# Altium schematic sheet Main.SchDoc
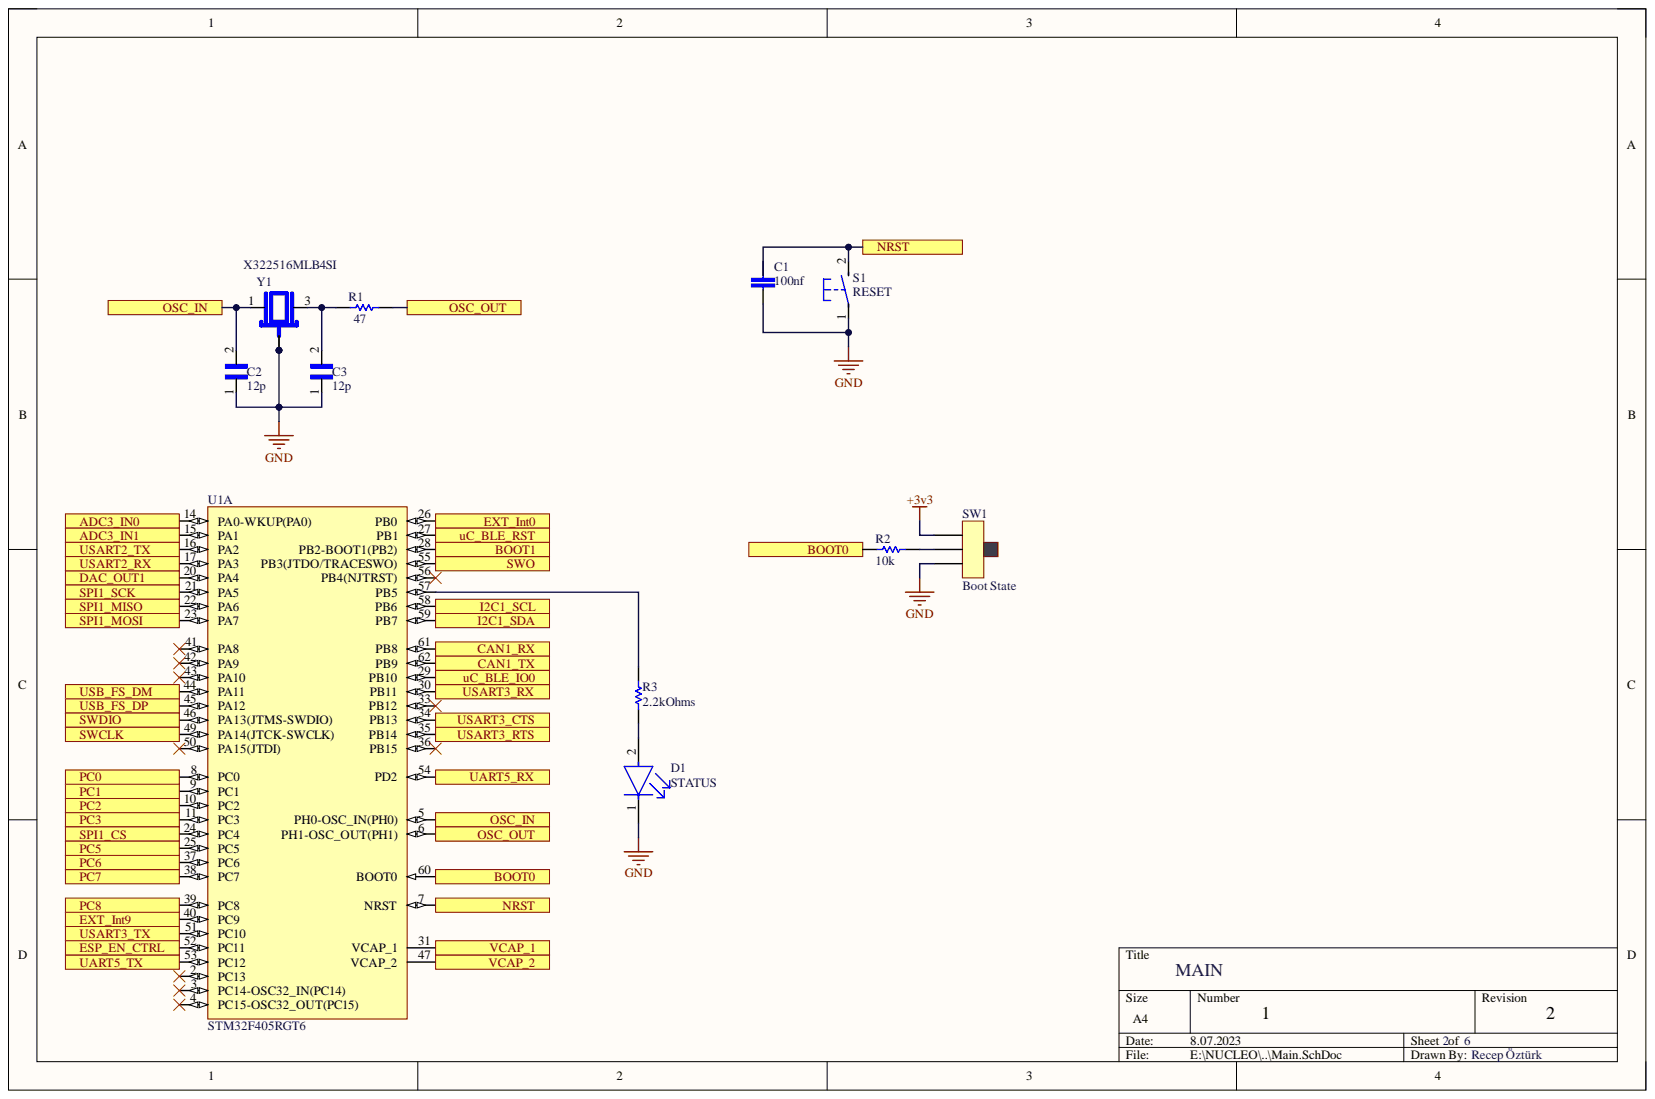
[diagram p0: Main.SchDoc]

## Parts
C1  100nf  [CAPC1005X55N]
C2  12p  [CAPC1005X55N]
C3  12p  [CAPC1005X55N]
D1  STATUS  [FP-APT1608QBC-D-MFG]
R1  value 47  [RESC1608X50N]  (hidden comment: Res1)
R2  value 10k  [RESC1608X50N]  (hidden comment: Res1)
R3  value 2.2kOhms  [RESC1608X50N]  (hidden comment: Res1)
S1  RESET  [DTS-3]
SW1  Boot State  [Pololu Mini Slide SW 2.5mm]
U1  STM32F405RGT6  [STM-LQFP64_M]  (part A)
Y1  X322516MLB4SI  [OSC_X322516MLB4SI]

## Ports
ADC3_IN0, ADC3_IN1, BOOT0, BOOT1, CAN1_RX, CAN1_TX, DAC_OUT1, ESP_EN_CTRL, EXT_Int0, EXT_Int9, I2C1_SCL, I2C1_SDA, NRST, OSC_IN, OSC_OUT, PC0, PC1, PC2, PC3, PC5, PC6, PC7, PC8, SPI1_CS, SPI1_MISO, SPI1_MOSI, SPI1_SCK, SWCLK, SWDIO, SWO, UART5_RX, UART5_TX, uC_BLE_IO0, uC_BLE_RST, USART2_RX, USART2_TX, USART3_CTS, USART3_RTS, USART3_RX, USART3_TX, USB_FS_DM, USB_FS_DP, VCAP_1, VCAP_2

## Nets
+3v3: SW1.1
GND: C1.2 C2.1 C3.1 D1.1 S1.1 SW1.3 Y1.2 Y1.4
ADC3_IN0: U1.14(PA0-WKUP(PA0)) ; port ADC3_IN0
ADC3_IN1: U1.15(PA1) ; port ADC3_IN1
BOOT0: R2.2 U1.60(BOOT0) ; port BOOT0
BOOT1: U1.28(PB2-BOOT1(PB2)) ; port BOOT1
CAN1_RX: U1.61(PB8) ; port CAN1_RX
CAN1_TX: U1.62(PB9) ; port CAN1_TX
DAC_OUT1: U1.20(PA4) ; port DAC_OUT1
ESP_EN_CTRL: U1.52(PC11) ; port ESP_EN_CTRL
EXT_Int0: U1.26(PB0) ; port EXT_Int0
EXT_Int9: U1.40(PC9) ; port EXT_Int9
I2C1_SCL: U1.58(PB6) ; port I2C1_SCL
I2C1_SDA: U1.59(PB7) ; port I2C1_SDA
NRST: C1.1 S1.2 U1.7(NRST) ; port NRST
OSC_IN: C2.2 U1.5(PH0-OSC_IN(PH0)) Y1.1 ; port OSC_IN
OSC_OUT: R1.2 U1.6(PH1-OSC_OUT(PH1)) ; port OSC_OUT
PC0: U1.8(PC0) ; port PC0
PC1: U1.9(PC1) ; port PC1
PC2: U1.10(PC2) ; port PC2
PC3: U1.11(PC3) ; port PC3
PC5: U1.25(PC5) ; port PC5
PC6: U1.37(PC6) ; port PC6
PC7: U1.38(PC7) ; port PC7
PC8: U1.39(PC8) ; port PC8
SPI1_CS: U1.24(PC4) ; port SPI1_CS
SPI1_MISO: U1.22(PA6) ; port SPI1_MISO
SPI1_MOSI: U1.23(PA7) ; port SPI1_MOSI
SPI1_SCK: U1.21(PA5) ; port SPI1_SCK
SWCLK: U1.49(PA14(JTCK-SWCLK)) ; port SWCLK
SWDIO: U1.46(PA13(JTMS-SWDIO)) ; port SWDIO
SWO: U1.55(PB3(JTDO/TRACESWO)) ; port SWO
UART5_RX: U1.54(PD2) ; port UART5_RX
UART5_TX: U1.53(PC12) ; port UART5_TX
uC_BLE_IO0: U1.29(PB10) ; port uC_BLE_IO0
uC_BLE_RST: U1.27(PB1) ; port uC_BLE_RST
USART2_RX: U1.17(PA3) ; port USART2_RX
USART2_TX: U1.16(PA2) ; port USART2_TX
USART3_CTS: U1.34(PB13) ; port USART3_CTS
USART3_RTS: U1.35(PB14) ; port USART3_RTS
USART3_RX: U1.30(PB11) ; port USART3_RX
USART3_TX: U1.51(PC10) ; port USART3_TX
USB_FS_DM: U1.44(PA11) ; port USB_FS_DM
USB_FS_DP: U1.45(PA12) ; port USB_FS_DP
VCAP_1: U1.31(VCAP_1) ; port VCAP_1
VCAP_2: U1.47(VCAP_2) ; port VCAP_2
NetC3_2: C3.2 R1.1 Y1.3
NetD1_2: D1.2 R3.2
NetR2_1: R2.1 SW1.2
NetR3_1: R3.1 U1.57(PB5)

Not connected: U1 2(PC13) 3(PC14-OSC32_IN(PC14)) 4(PC15-OSC32_OUT(PC15)) 33(PB12) 36(PB15) 41(PA8) 42(PA9) 43(PA10) 50(PA15(JTDI)) 56(PB4(NJTRST))

## Library data of the parts
C1 = CL05B104KO5NFNC: 100nF 16v 0402  LCSC = C1525; MF: {'manufacturer': u'Samsung'}; Availability: Unavailable; Component Kind: Standard; Library Name: SnapEDA_Library.IntLib; MP: CL05B104KO5NFNC; Package: None; Price: None
C2 C3 = GRM0225C1H120JA03L: Multilayer Ceramic Capacitors MLCC - SMD/SMT 12pF 50V 0402 RoHS  LCSC = C1547; MF: {'manufacturer': u'Murata'}; Availability: Unavailable; Component Kind: Standard; Library Name: SnapEDA_Library.IntLib; MP: GRM0225C1H120JA03L; Package: None; Price: None
D1 = CMP-2000-05788-2: Light Emitting Diodes (LED) Blue 465~475nm 11.5~28.5mcd@5mA Top View 0603 RoHS C72041; Dominant Wavelength: 465nm; Forward Current: 20mA; Forward Voltage: 3.3V; Lens Color: Colorless; Lens Style: Flat; Lens Transparency: Clear; Luminous Intensity: 100mcd; Number of LEDs: 1; Viewing Angle: 120°; Case Code (Metric): 1608; Case Code (Imperial): 0603; Case/Package: 0603; Color: Blue; Component Kind: Standard; Height: 0.75mm; Lead Free: Lead Free; Length: 1.6mm; Library Name: Altium Content Vault; Max Operating Temperature: 85°C; Min Operating Temperature: -40°C; Mount: Surface Mount; Number of Pins: 2; Package Quantity: 2000; Packaging: Tape and Reel; Test Current: 20mA; Width: 0.8mm; Illumination Color: Blue
R1 = Res1: Chip Resistor - Surface Mount 47Ohms ±1% 1/10W 0603 RoHS  LCSC = C23182; Component Kind: Standard; LatestRevisionDate: 17-Jul-2002; LatestRevisionNote: Re-released for DXP Platform.; Library Name: Miscellaneous Devices.IntLib; PackageDescription: Axial Device, Thru-Hole; 2 Leads; 0.3 in Pin Spacing; PackageReference: AXIAL-0.3; Published: 8-Jun-2000; Signal Integrity: Res; Simulation: RESISTOR; Publisher: Altium Limited
R2 = Res1: CHIP RESISTOR - SURFACE MOUNT 10KOHMS ±1% 1/10W 0603 ROHS  LCSC = C25804; Component Kind: Standard; LatestRevisionDate: 17-Jul-2002; LatestRevisionNote: Re-released for DXP Platform.; Library Name: Miscellaneous Devices.IntLib; PackageDescription: Axial Device, Thru-Hole; 2 Leads; 0.3 in Pin Spacing; PackageReference: AXIAL-0.3; Published: 8-Jun-2000; Signal Integrity: Res; Simulation: RESISTOR; Publisher: Altium Limited
R3 = Res1: Chip Resistor - Surface Mount 2.2KOhms ±1% 1/10W 0603 RoHS C4190; Component Kind: Standard; LatestRevisionDate: 17-Jul-2002; LatestRevisionNote: Re-released for DXP Platform.; Library Name: Miscellaneous Devices.IntLib; PackageDescription: Axial Device, Thru-Hole; 2 Leads; 0.3 in Pin Spacing; PackageReference: AXIAL-0.3; Published: 8-Jun-2000; Signal Integrity: Res; Simulation: RESISTOR; Publisher: Altium Limited
S1 = DTS-3: MF: {'manufacturer': u'APEM Inc.'}; Availability: Unavailable; Component Kind: Standard; Library Name: SnapEDA_Library.IntLib; MP: DTS-3; Package: None; Price: None
SW1 = Mini Slide Switch 2mm: 3 Pin SPDT Mini On Off Switch; Component Kind: Standard; Library Name: Schlib1.SchLib
U1 = CMP-0243-00019-3: ARM Cortex-M4 32-bit MCU+FPU, 210 DMIPS, 1024 kB Flash, 192 kB Internal RAM, 51 I/Os, 64-pin LQFP  LCSC= C15742; Manufacturer: STMicroelectronics; D/A Converter: 2x12-bit; FLASH Size (Prog) (kB): 1024; Operating Frequency(F) (Processor speed) (MHz): 168; Packing: Tray; Supply Voltage(Vcc) max (V): 3.6; Supply Voltage(Vcc) min (V): 1.8; I/Os (High Current): 51; Internal RAM Size (kB): 192; Component Kind: Standard; ComponentLink1Description: Manufacturer URL; ComponentLink1URL: http://www.st.com/web/en/home.html; ComponentLink2Description: Datasheet; ComponentLink2URL: http://www.st.com/st-web-ui/static/active/en/resource/technical/document/datasheet/DM00037051.pdf; ComponentLink3Description: Package Specification; ComponentLink3URL: http://www.st.com/st-web-ui/static/active/en/resource/technical/document/datasheet/DM00037051.pdf; Core: ARM Cortex-M4; DatasheetVersion: Rev. 4; Library Name: Schlib1.SchLib; Mounting Technology: Surface Mount; PackageDescription: 64-Pin Low Profile Quad Flat Package, 10 x 10 mm Body, 0.5 mm Pitch; PackageReference: LQFP64; PackageVersion: Rev. 4, 06/2013; PartNumber: STM32F405RGT6
Y1 = X322516MLB4SI: 16MHz ±10ppm SMD-3225_4P  LCSC = C13738; MF: YXC; Availability: Unavailable; Check_prices: https://www.snapeda.com/parts/X322516MLB4SI/YXC/view-part/4703090/?ref=eda; Component Kind: Standard; Library Name: X322516MLB4SI.IntLib; MP: X322516MLB4SI; Package: Package; Price: None; SnapEDA_Link: https://www.snapeda.com/parts/X322516MLB4SI/YXC/view-part/4703090/?ref=snap
